# Altium schematic sheet foxbms2-interface-ltc6820.SchDoc(foxbms2-interface-ltc6820)
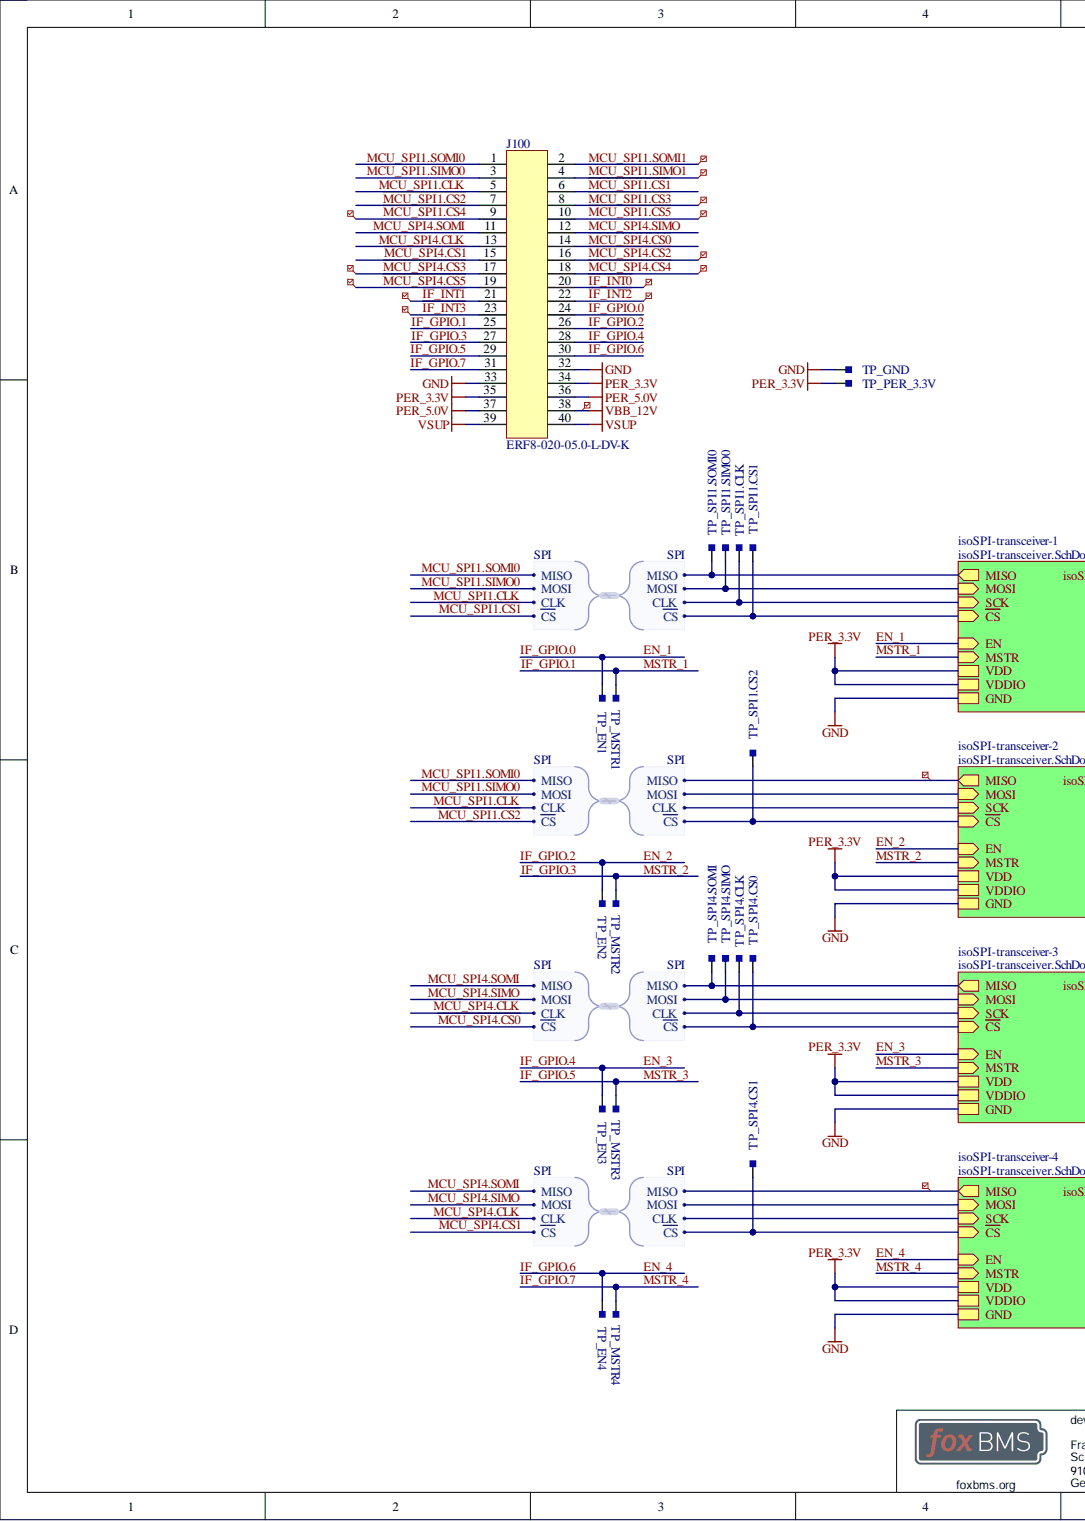
[diagram p0: foxbms2-interface-ltc6820.SchDoc, left]
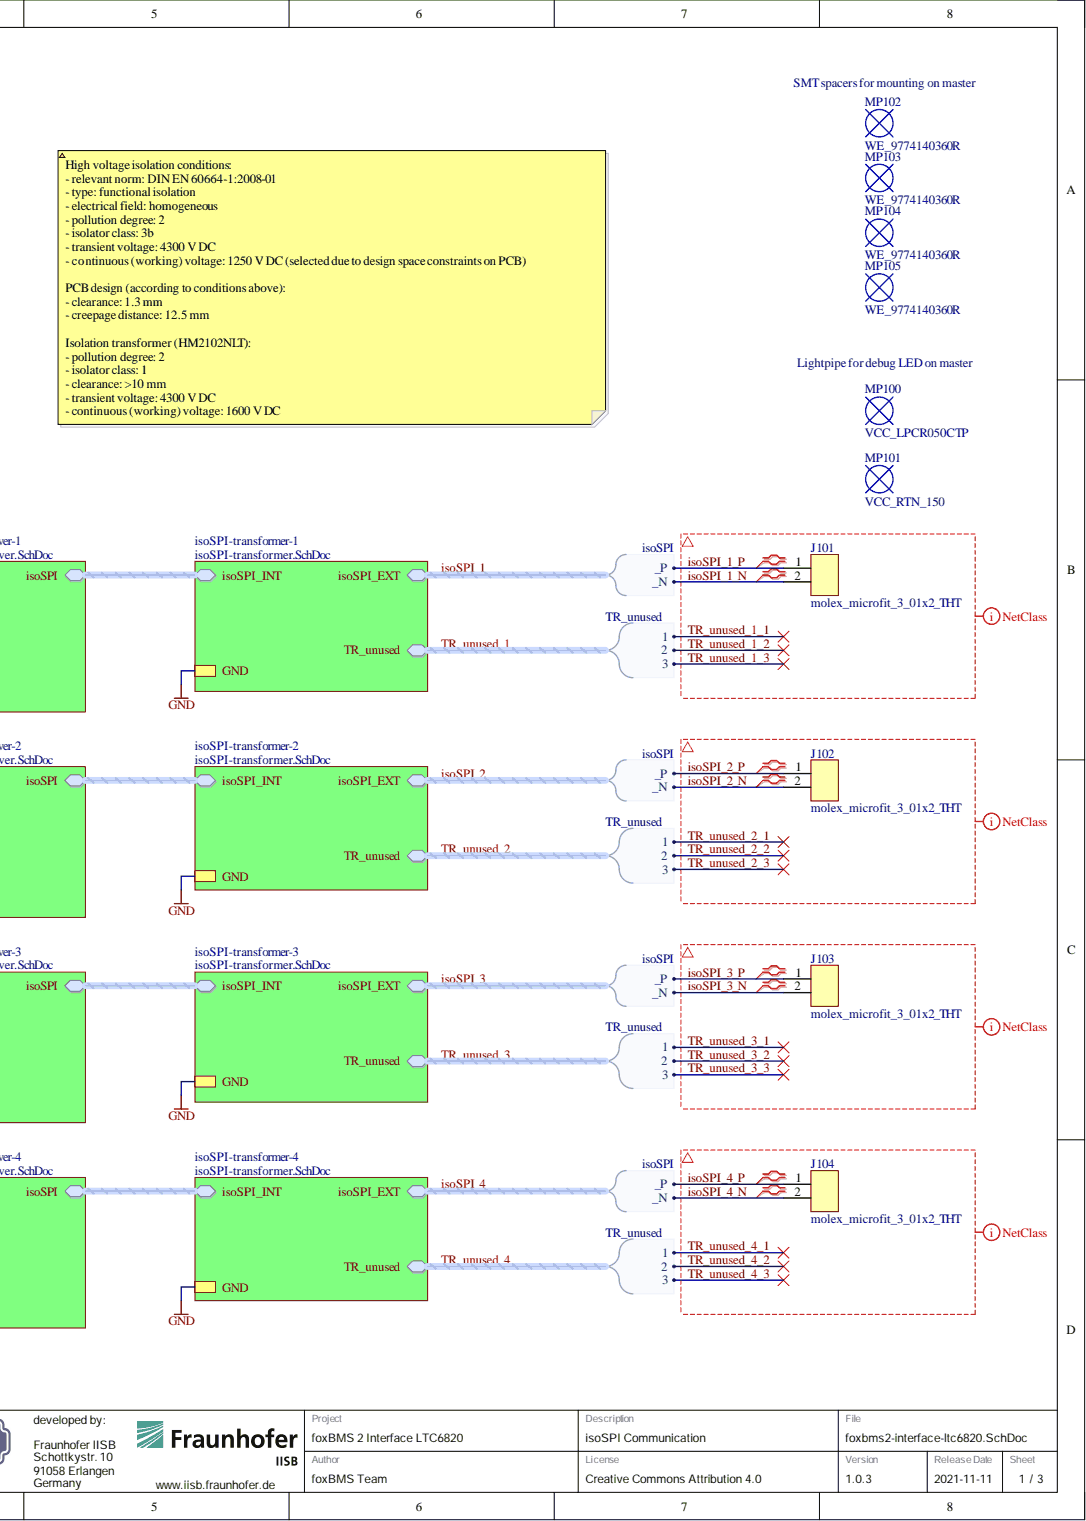
[diagram p1: foxbms2-interface-ltc6820.SchDoc, right]

## Parts
J100  ERF8-020-05.0-L-DV-K  [SAMTEC_ERF8-020-05.0-L-DV-K]
J101  molex_microfit_3_01x2_THT  [MOLEX_MICROFIT_01x2_THT]
J102  molex_microfit_3_01x2_THT  [MOLEX_MICROFIT_01x2_THT]
J103  molex_microfit_3_01x2_THT  [MOLEX_MICROFIT_01x2_THT]
J104  molex_microfit_3_01x2_THT  [MOLEX_MICROFIT_01x2_THT]
MP100  VCC_LPCR050CTP  [VCC_LPCR050TP]  (mechanical)
MP101  VCC_RTN_150  [VCC_RTN_150]  (mechanical)
MP102  WE_9774140360R  [WE_9774140360]
MP103  WE_9774140360R  [WE_9774140360]
MP104  WE_9774140360R  [WE_9774140360]
MP105  WE_9774140360R  [WE_9774140360]
TP_EN1  Testpoint_Square  [Testpoint_S1]  (value not drawn)  (no BOM)
TP_EN2  Testpoint_Square  [Testpoint_S1]  (value not drawn)  (no BOM)
TP_EN3  Testpoint_Square  [Testpoint_S1]  (value not drawn)  (no BOM)
TP_EN4  Testpoint_Square  [Testpoint_S1]  (value not drawn)  (no BOM)
TP_GND  Testpoint_Square  [Testpoint_S1]  (value not drawn)  (no BOM)
TP_MSTR1  Testpoint_Square  [Testpoint_S1]  (value not drawn)  (no BOM)
TP_MSTR2  Testpoint_Square  [Testpoint_S1]  (value not drawn)  (no BOM)
TP_MSTR3  Testpoint_Square  [Testpoint_S1]  (value not drawn)  (no BOM)
TP_MSTR4  Testpoint_Square  [Testpoint_S1]  (value not drawn)  (no BOM)
TP_PER_3.3V  Testpoint_Square  [Testpoint_S1]  (value not drawn)  (no BOM)
TP_SPI1.CLK  Testpoint_Square  [Testpoint_S1]  (value not drawn)  (no BOM)
TP_SPI1.CS1  Testpoint_Square  [Testpoint_S1]  (value not drawn)  (no BOM)
TP_SPI1.CS2  Testpoint_Square  [Testpoint_S1]  (value not drawn)  (no BOM)
TP_SPI1.SIMO0  Testpoint_Square  [Testpoint_S1]  (value not drawn)  (no BOM)
TP_SPI1.SOMI0  Testpoint_Square  [Testpoint_S1]  (value not drawn)  (no BOM)
TP_SPI4.CLK  Testpoint_Square  [Testpoint_S1]  (value not drawn)  (no BOM)
TP_SPI4.CS0  Testpoint_Square  [Testpoint_S1]  (value not drawn)  (no BOM)
TP_SPI4.CS1  Testpoint_Square  [Testpoint_S1]  (value not drawn)  (no BOM)
TP_SPI4.SIMO  Testpoint_Square  [Testpoint_S1]  (value not drawn)  (no BOM)
TP_SPI4.SOMI  Testpoint_Square  [Testpoint_S1]  (value not drawn)  (no BOM)

## Nets
GND: J100.32 J100.33 TP_GND.1
PER_3.3V: J100.34 J100.35 TP_PER_3.3V.1
PER_5.0V: J100.36 J100.37
VBB_12V: J100.38
VSUP: J100.39 J100.40
~{IF_INT0}: J100.20
~{IF_INT1}: J100.21
~{IF_INT2}: J100.22
~{IF_INT3}: J100.23
~{MCU_SPI1.CS1}: J100.6 TP_SPI1.CS1.1
~{MCU_SPI1.CS2}: J100.7 TP_SPI1.CS2.1
~{MCU_SPI1.CS3}: J100.8
~{MCU_SPI1.CS4}: J100.9
~{MCU_SPI1.CS5}: J100.10
~{MCU_SPI4.CS0}: J100.14 TP_SPI4.CS0.1
~{MCU_SPI4.CS1}: J100.15 TP_SPI4.CS1.1
~{MCU_SPI4.CS2}: J100.16
~{MCU_SPI4.CS3}: J100.17
~{MCU_SPI4.CS4}: J100.18
~{MCU_SPI4.CS5}: J100.19
EN_1: J100.24 TP_EN1.1
EN_2: J100.26 TP_EN2.1
EN_3: J100.28 TP_EN3.1
EN_4: J100.30 TP_EN4.1
IF_GPIO.1: J100.25 TP_MSTR1.1
IF_GPIO.3: J100.27 TP_MSTR2.1
IF_GPIO.5: J100.29 TP_MSTR3.1
IF_GPIO.7: J100.31 TP_MSTR4.1
isoSPI_1._N: J101.2
isoSPI_1._P: J101.1
isoSPI_2._N: J102.2
isoSPI_2._P: J102.1
isoSPI_3._N: J103.2
isoSPI_3._P: J103.1
isoSPI_4._N: J104.2
isoSPI_4._P: J104.1
MCU_SPI1.CLK: J100.5 TP_SPI1.CLK.1
MCU_SPI1.SIMO0: J100.3 TP_SPI1.SIMO0.1
MCU_SPI1.SIMO1: J100.4
MCU_SPI1.SOMI0: J100.1 TP_SPI1.SOMI0.1
MCU_SPI1.SOMI1: J100.2
MCU_SPI4.CLK: J100.13 TP_SPI4.CLK.1
MCU_SPI4.SIMO: J100.12 TP_SPI4.SIMO.1
MCU_SPI4.SOMI: J100.11 TP_SPI4.SOMI.1
isoSPI._N:
isoSPI._P:
TR_unused_1.1:
TR_unused_1.2:
TR_unused_1.3:
TR_unused_2.1:
TR_unused_2.2:
TR_unused_2.3:
TR_unused_3.1:
TR_unused_3.2:
TR_unused_3.3:
TR_unused_4.1:
TR_unused_4.2:
TR_unused_4.3:

## Library data of the parts
J100 = SAMTEC_ERF8-020-05.0-L-DV-K: Edge Rate rugged high-speed connector strips; Manufacturer: Samtec; Automotive Qualified: False; Circuits: 40; Component Kind: Standard; ComponentLink1Description: Datasheet; ComponentLink1URL: https://suddendocs.samtec.com/catalog_english/erf8-s.pdf; Database Table Name: Connector; ECCN: EAR99; Library Name: OE750.DbLib; Operating Temperature: -55°C - +125°C; Part Name: ERF8-020-05.0-L-DV-K; Part Number: SAMTEC_ERF8-020-05.0-L-DV-K; Supplier 1: Farnell; Supplier 2: Digikey; Supplier 3: Mouser; Supplier Part Number 1: 3771957; Supplier Part Number 2: SAM13550CT-ND; Supplier Part Number 3: 200-ERF802005.0LDVLK; System: Connector
J101-J104 = molex_microfit_3_01x2_THT: Micro-Fit 3.0 Right Angle Header, 3.00mm Pitch, Dual Row, 2 Circuits, with Snap-in Plastic Peg PCB Lock, Tin, Glow Wire Capable, Black, 43045-0200; Manufacturer: Molex; Automotive Qualified: False; Circuits: 2; Database Table Name: Connector; Rated Current: 8.5A; Rated Voltage: 600V; Component Kind: Standard; Library Name: OE750.DbLib; Operating Temperature: -40°C - +105°C; Part Name: MicroFit; Part Number: molex_microfit_3_01x2_THT; Supplier 1: Farnell; Supplier Part Number 1: 1012251
MP100 = VCC_LPCR050CTP: Lightpipe Clear LPCR Series; Manufacturer: VCC; Automotive Qualified: False; Database Table Name: Misc; ComponentLink1Description: Datasheet; ComponentLink1URL: https://vcclite.com/wp-content/uploads/wpallimport/files/files/LPCR.pdf; ECCN: EAR99; Library Name: OE750.DbLib; Part Name: VCC_LPCR050CTP; Part Number: VCC_LPCR050CTP; Supplier 1: Digikey; Supplier 2: Mouser; Supplier Part Number 1: LPCR050CTP-ND; Supplier Part Number 2: 593-LPCR050CTP
MP101 = VCC_RTN_150: Grommet Retainer for LPC and LPCR Series Light Pipe; Manufacturer: VCC; Automotive Qualified: False; Database Table Name: Misc; ComponentLink1Description: Datasheet; ComponentLink1URL: https://vcclite.com/?file=files/RTN_150.pdf&file_name=RTN_150.pdf; ECCN: EAR99; Library Name: OE750.DbLib; Part Name: VCC_RTN_150; Part Number: VCC_RTN_150; Supplier 1: Digikey; Supplier 2: Mouser; Supplier Part Number 1: RTN_150-ND; Supplier Part Number 2: 593-RTN150
MP102-MP105 = WE_9774140360R: WA-SMSI SMT Steel Spacer with internal thread M 3; Manufacturer: Würth Elektronik; Automotive Qualified: False; Database Table Name: Misc; Component Kind: Standard; ComponentLink1Description: Datasheet; ComponentLink1URL: https://www.we-online.com/catalog/datasheet/9774140360R.pdf; ECCN: EAR99; Library Name: OE750.DbLib; Operating Temperature: -55°C - +150°C; Part Name: WE_9774140360R; Part Number: WE_9774140360R; Supplier 1: Digikey; Supplier 2: Mouser; Supplier Part Number 1: 732-5281-1-ND; Supplier Part Number 2: 710-9774140360R
TP_EN1-TP_EN4 TP_GND TP_MSTR1-TP_MSTR4 TP_PER_3.3V TP_SPI1.CLK TP_SPI1.CS1 TP_SPI1.CS2 TP_SPI1.SIMO0 TP_SPI1.SOMI0 TP_SPI4.CLK TP_SPI4.CS0 TP_SPI4.CS1 TP_SPI4.SIMO TP_SPI4.SOMI = Testpoint_Square: Testpoint, square; Automotive Qualified: False; Database Table Name: Misc (No BOM); Library Name: OE750.DbLib; Part Number: Testpoint_Square
